# Altium schematic sheet 03_pushswitch.SchDoc(03_pushswitch)
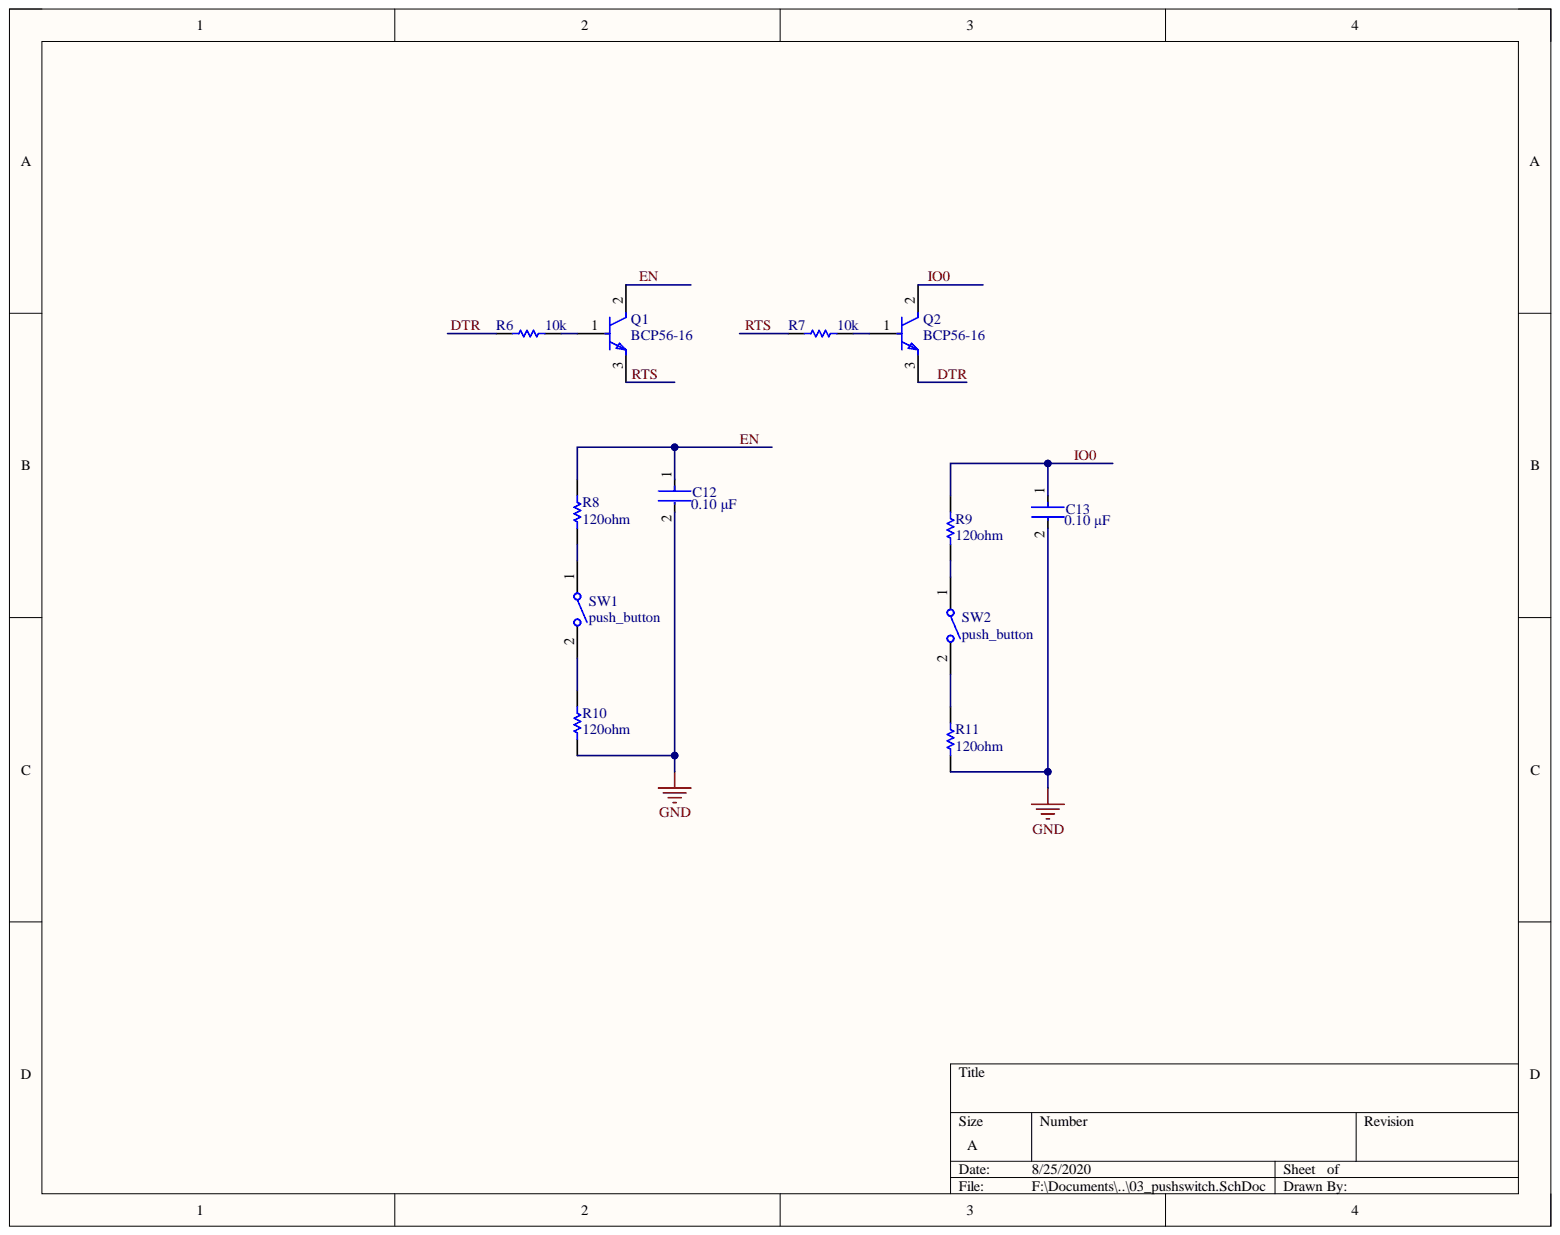
[diagram p0: 03_pushswitch.SchDoc]

## Parts
C12  value 0.10 μF  [FP-C0603C-CF-MFG]  (hidden comment: C0603_0.1UF)
C13  value 0.10 μF  [FP-C0603C-CF-MFG]  (hidden comment: C0603_0.1UF)
Q1  BCP56-16  [FP-SOT-223-P008-MFG]
Q2  BCP56-16  [FP-SOT-223-P008-MFG]
R6  value 10k  [RESC1609X55X30LL15T15]  (hidden comment: R0603_10K)
R7  value 10k  [RESC1609X55X30LL15T15]  (hidden comment: R0603_10K)
R8  value 120ohm  [RESC1608X55X30NL20T20]  (hidden comment: R0603_120R)
R9  value 120ohm  [RESC1608X55X30NL20T20]  (hidden comment: R0603_120R)
R10  value 120ohm  [RESC1608X55X30NL20T20]  (hidden comment: R0603_120R)
R11  value 120ohm  [RESC1608X55X30NL20T20]  (hidden comment: R0603_120R)
SW1  push_button  [TECO-FSMSMTR_V]
SW2  push_button  [TECO-FSMSMTR_V]

## Nets
GND: C12.2 C13.2 R10.1 R11.1
DTR: Q2.3 R6.1
EN: C12.1 Q1.2 R8.2
IO0: C13.1 Q2.2 R9.2
RTS: Q1.3 R7.1
NetQ1_1: Q1.1 R6.2
NetQ2_1: Q2.1 R7.2
NetR8_1: R8.1 SW1.1
NetR9_1: R9.1 SW2.1
NetR10_2: R10.2 SW1.2
NetR11_2: R11.2 SW2.2

## Library data of the parts
C12 C13 = CMP-03020-016578-1: CAP CER 0.1UF 16V X7R 0603; Manufacturer: KEMET; Manufacturer Part Number: C0603C104M4RAC7411; Automotive Grade: Grade 1; Material: Ceramic; Temperature Coefficient: 30ppm/°C; Tolerance: 0.2; Automotive: Yes; Capacitance: 0.10 μF; Category: Cap; Component Kind: Standard; ComponentLink2Description: Datasheet; ComponentLink2URL: https://api.kemet.com/component-edge/download/datasheet/C0603C100J5GACAUTO.pdf; Device Class L1: Passive Components; Device Class L2: Capacitors; Device Class L3: Ceramic Capacitors; Digikey Description: CAP CER 0.1UF 16V X7R 0603; Height: 0.87mm; Lead Free: Yes; Library Name: Altium Content Vault; Mouser Description: Multilayer Ceramic Capacitors MLCC - SMD/SMT 16volts 0.1uF X7R 20%; Octopart: https://octopart.com/c0603c104m4rac7411-kemet-9138805; Package: C0603C-CF; RoHS: TRUE; Temperature: -55°C to +125°C; Temperature Characteristic: X7R; Temperature Range High: +125°C; Temperature Range Low: -55°C; Voltage Rating: 16 V
Q1 Q2 = CMP-12195-000003-1: TRANS NPN 80V 1A SOT-223; Manufacturer: STMicroelectronics; Collector Base Voltage: 100V; Collector Emitter Saturation Voltage: 0.5V; Emitter Base Voltage: 5V; Hfe Max: 250; Hfe Min: 100; Hfe Testic: 150mA; Hfe Testvce: 2V; Max Junction Temp: +150°C; Number Of Elements: 1; Power Dissipation: 1.6W; Rthja Max: 78°C/W; Standoff Height: 0.02mm; Automotive: No; Category: Trans; Collector Emitter Breakdown Voltage: 80V; Collector Emitter Voltage: 80V; Component Kind: Standard; ComponentLink2Description: Datasheet; ComponentLink2URL: https://www.st.com/resource/en/datasheet/bcp56-16.pdf; Device Class L1: Discrete Semiconductors; Device Class L2: Transistors; Device Class L3: BJTs; Digikey Description: TRANS NPN 80V 1A SOT-223; Footprint Url: https://www.st.com/content/ccc/resource/technical/document/application_note/45/cc/69/ea/2f/8d/4e/3c/CD00004438.pdf/files/CD00004438.pdf/jcr:content/translations/en.CD00004438.pdf; Height: 1.82mm; Ipc Land Pattern Name: SOT230P700X180-4; Lead Free: Yes; Library Name: Altium Content Vault; Manufacturer Part Number: BCP56-16; Max Collector Current: 1A; Mouser Description: BCP56 Series 80 V 1 A 1.6 W Surface Mount Low Power NPN Transistor - SOT-223; Number Of Npn: 1; Octopart: https://octopart.com/bcp56-16-stmicroelectronics-13883839; Package: SOT-223-P008; RoHS: TRUE; Temperature: to +150°C; Temperature Range High: +150°C
R6 R7 = CMP-2000-07452-1: Chip Resistor, 10 KOhm, +/- 1%, 0.1 W, -55 to 155 degC, 0603 (1608 Metric), RoHS, Tape and Reel; Manufacturer: Panasonic; Case\/Package: 0603; Max Power Dissipation: 100mW; Resistance: 10KOhm; Temperature Coefficient: 100ppm\/degreesC; Voltage Rating: 75V; Case Code (Imperial): 0603; Case Code (Metric): 1608; Component Kind: Standard; Composition: ThickFilm; Contact Plating: Tin; Datasheet URL: https://industrial.panasonic.com/cdbs/www-data/pdf/RDA0000/AOA0000C304.pdf; Datasheet Version: 02/2017; Depth: 0.8mm; Height: 0.45mm; Lead Free: LeadFree; Length: 1.6mm; Library Name: Altium Content Vault; Manufacturer URL: http://www.panasonic.com/; Max Operating Temperature: 155degC; Military Standard: Not; Min Operating Temperature: -55degC; Mount: SurfaceMount; Mounting Technology: SurfaceMount; Number of Pins: 2; Number of Terminations: 2; Package Description: 2-Pin Surface Mount Device, Body 1.6 x 0.85 mm; Package Quantity: 1; Package Reference: 603; Packaging: TapeandReel; Power Rating: 100mW; Radiation Hardening: No; REACH SVHC: NoSVHC; RoHSCompliant: true; Termination: SMD/SMT; Tolerance: 1%; Voltage Rating (DC): 75V; Width: 0.031inch
R8-R11 = CMP-2000-00896-1: Manufacturer: Panasonic; Part Number: ERA-3AEB121V; Component Kind: Standard; Library Name: Altium Content Vault
SW1 SW2 = CMP-2000-07501-1: FSMSM Push Button Switch, 50 mA, -35 to 85 degC, 2-Pin SMD, RoHS, Tape and Reel; Manufacturer: TE Connectivity; Actuator Length: 1.07mm; Actuator Material: Polyamide; Case\/Package: SMD/SMT; Contact Current Rating: 50mA; Contact Resistance: 100mOhm; Electrical Life: 20000Cycles; Illumination Type: Illuminated; Max Voltage Rating (DC): 12V; Operating Force: 180gf; Switch Travel: 0.25mm; Throw Configuration: SPST; Voltage Rating (DC): 24V; Component Kind: Standard; Contact Material: Brass; Contact Plating: Silver; Current Rating: 50mA; Datasheet URL: http://www.te.com/commerce/DocumentDelivery/DDEController?Action=showdoc&DocId=Customer+Drawing%7F1437566-3%7FC2%7Fpdf%7FEnglish%7FENG_CD_1437566-3_C2_1437566_3_1_c.dwg.pdf%7F1437566-4; Datasheet Version: Rev. C2, 08/2014; ELV: Compliant; Lead Free: LeadFree; Library Name: Altium Content Vault; Manufacturer URL: http://www.te.com/; Max Operating Temperature: 85degC; Mechanical Life: 20000Cycles; Min Operating Temperature: -35degC; Mount: SurfaceMount; Mounting Technology: SurfaceMount; Orientation: Straight; Package Description: 2-Pin Surface Mount Device, Body 6 x 3.5 mm, Pitch 9.19 mm; Package Quantity: 1800; Package Reference: FSMSMTR; Packaging: TapeandReel; REACH SVHC: Unknown; RoHSCompliant: true; Termination: GullWing
